# Altium schematic sheet Sheet3.SchDoc
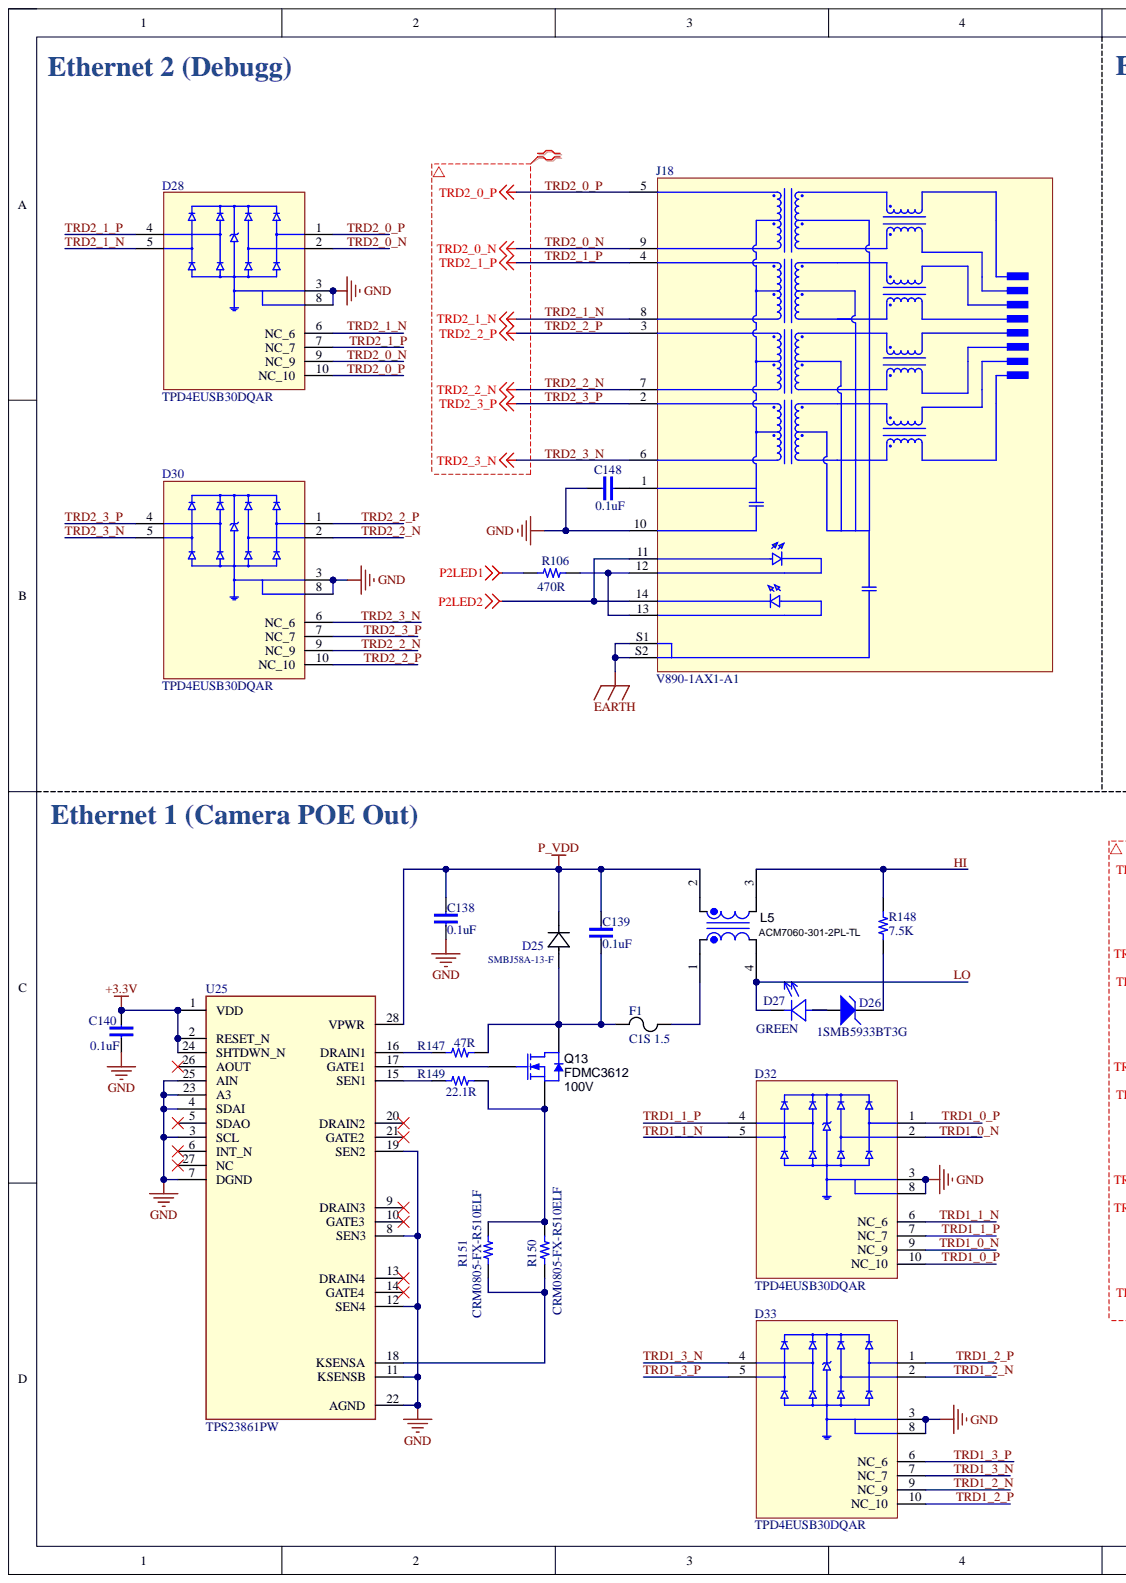
[diagram p0: Sheet3.SchDoc, left]
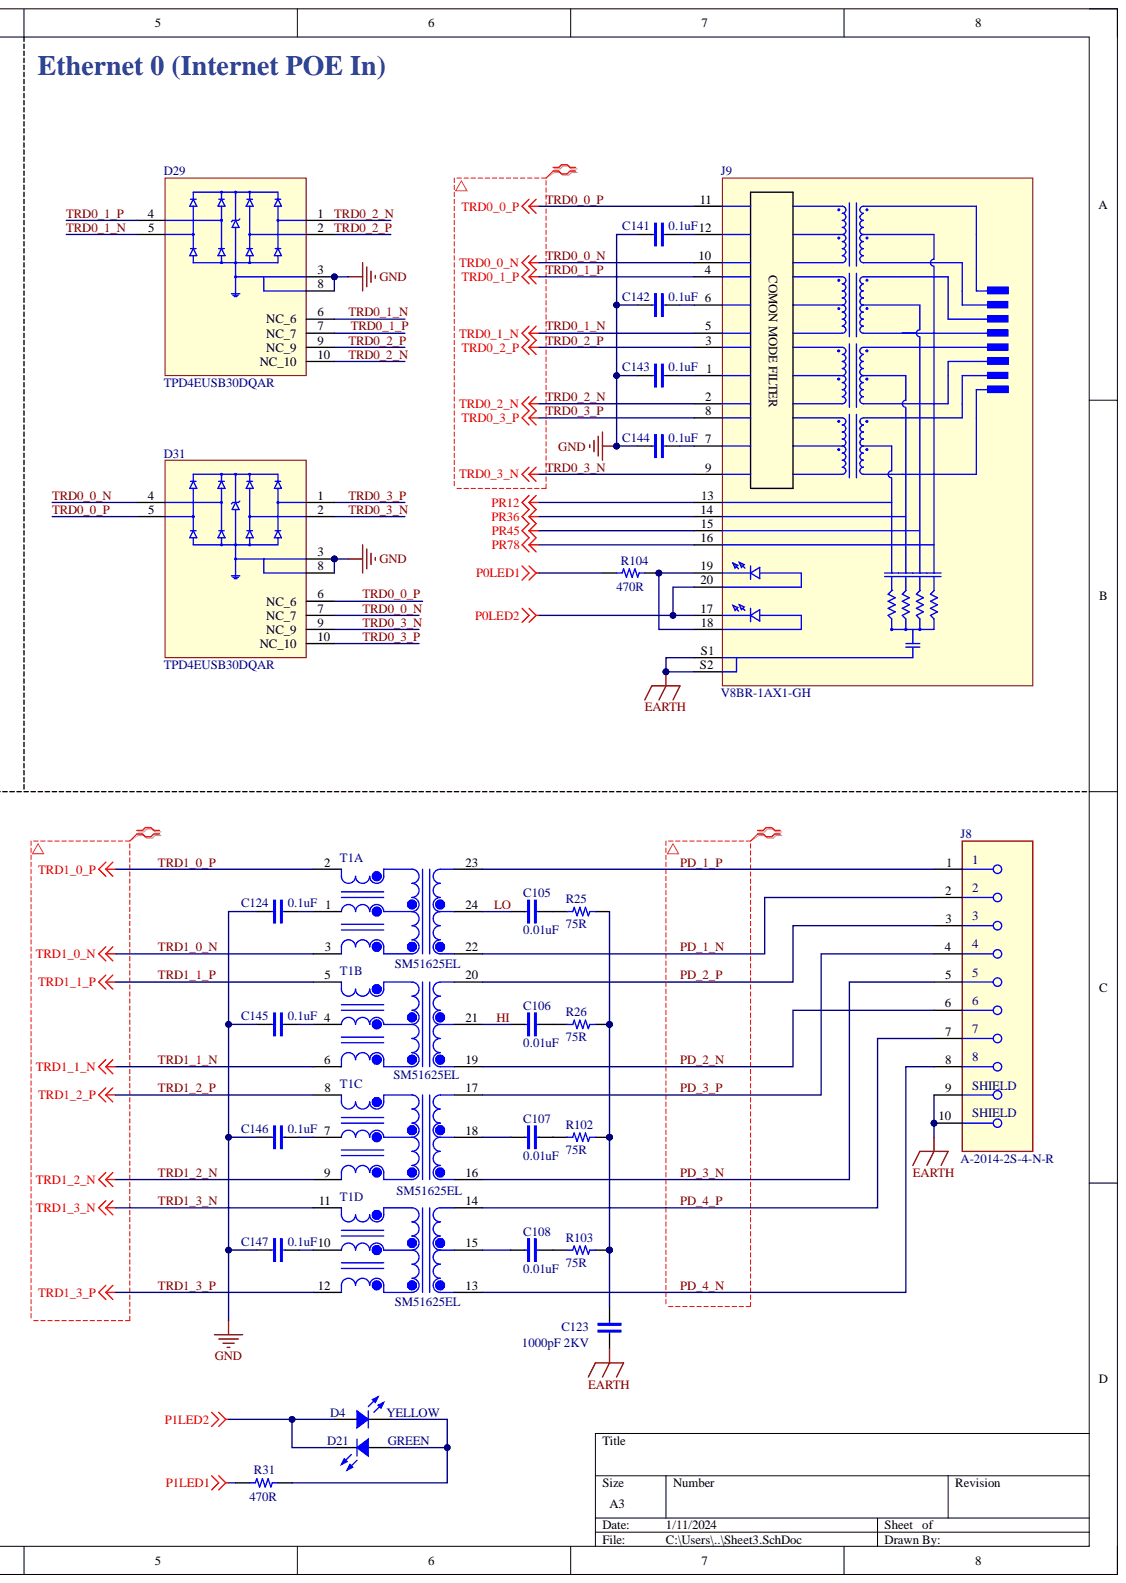
[diagram p1: Sheet3.SchDoc, right]

## Parts
C105  (no value)  [C-0402/1005]
C106  (no value)  [C-0402/1005]
C107  (no value)  [C-0402/1005]
C108  (no value)  [C-0402/1005]
C123  (no value)  [C-0402/1005]
C124  (no value)  [C-0402/1005]
C138  (no value)  [C-0402/1005]
C139  (no value)  [C-0402/1005]
C140  (no value)  [C-0402/1005]
C141  (no value)  [C-0402/1005]
C142  (no value)  [C-0402/1005]
C143  (no value)  [C-0402/1005]
C144  (no value)  [C-0402/1005]
C145  (no value)  [C-0402/1005]
C146  (no value)  [C-0402/1005]
C147  (no value)  [C-0402/1005]
C148  (no value)  [C-0402/1005]
D4  (no value)  [LED-0603-RED]
D21  (no value)  [LED-0603-RED]
D25  Manufacturer_Part_Number: SMBJ58A-13-F  [CR_SM12CA-13-F_DIO]
D26  PartNumber: 1SMB5933BT3G  [ONSC-SMB-2-403A-03_H_V]
D27  (no value)  [LED-0603-Green]
D28  MP: TPD4EUSB30DQAR  [IC_TPD4EUSB30DQAR]
D29  MP: TPD4EUSB30DQAR  [IC_TPD4EUSB30DQAR]
D30  MP: TPD4EUSB30DQAR  [IC_TPD4EUSB30DQAR]
D31  MP: TPD4EUSB30DQAR  [IC_TPD4EUSB30DQAR]
D32  MP: TPD4EUSB30DQAR  [IC_TPD4EUSB30DQAR]
D33  MP: TPD4EUSB30DQAR  [IC_TPD4EUSB30DQAR]
F1  Manufacturer_Part_Number: C1S 1.5  [C1_BEL]
J8  (no value)  [ASSMANN_A-2014-2S-4-N-R]
J9  Manufacturer_Part_Number: V8BR-1AX1-GH  [V8BR1AX1GH]
J18  MP: V890-1AX1-A1  [BEL_V890-1AX1-A1]
L5  ComponentName: ACM7060-301-2PL-TL  [IND_ACM7060_TDK]
Q13  value 100V  PartNumber: FDMC3612  [MLP8_3P3X3P3_ONS]
R25  (no value)  [R-0402/1005]
R26  (no value)  [R-0402/1005]
R31  (no value)  [R-0402/1005]
R102  (no value)  [R-0402/1005]
R103  (no value)  [R-0402/1005]
R104  (no value)  [R-0402/1005]
R106  (no value)  [R-0402/1005]
R147  (no value)  [R-0402/1005]
R148  (no value)  [R-0805/2012]
R149  (no value)  [R-0402/1005]
R150  (no value)  [R-0805/2012]
R151  (no value)  [R-0805/2012]
T1  (no value)  [XFMR_SM51625EL]  (parts A,B,C,D)
U25  Manufacturer_Part_Number: TPS23861PW  [PW0028A_N]

## Nets
+3.3V: C140.1 U25.1(VDD) U25.2(RESET_N) U25.24(SHTDWN_N)
EARTH: C123.2 J8.9 J8.10 J9.S1 J9.S2 J18.S1 J18.S2
GND: C124.1 C138.2 C140.2 C141.1 C142.1 C143.1 C144.1 C145.1 C146.1 C147.1 C148.1 D28.3 D28.8 D29.3 D29.8 D30.3 D30.8 D31.3 D31.8 D32.3 D32.8 D33.3 D33.8 J18.10 U25.3(SCL) U25.4(SDAI) U25.7(DGND) U25.8(SEN3) U25.11(KSENSB) U25.12(SEN4) U25.19(SEN2) U25.22(AGND) U25.23(A3) U25.25(AIN)
P0LED1: R104.1
P0LED2: J9.17 J9.20
P1LED1: R31.1
P1LED2: D4.1 D21.2
P2LED1: R106.1
P2LED2: J18.11 J18.14
P_VDD: C138.1 C139.1 D25.1 L5.2 U25.28(VPWR)
PR12: J9.13
PR36: J9.14
PR45: J9.15
PR78: J9.16
HI: C106.1 L5.3 R148.2 T1.21
LO: C105.1 D27.2 L5.4 T1.24
PD_1_N: J8.2 T1.22
PD_1_P: J8.1 T1.23
PD_2_N: J8.6 T1.19
PD_2_P: J8.3 T1.20
PD_3_N: J8.5 T1.16
PD_3_P: J8.4 T1.17
PD_4_N: J8.8 T1.13
PD_4_P: J8.7 T1.14
TRD0_0_N: D31.4 D31.7(NC_7) J9.10
TRD0_0_P: D31.5 D31.6(NC_6) J9.11
TRD0_1_N: D29.5 D29.6(NC_6) J9.5
TRD0_1_P: D29.4 D29.7(NC_7) J9.4
TRD0_2_N: D29.1 D29.10(NC_10) J9.2
TRD0_2_P: D29.2 D29.9(NC_9) J9.3
TRD0_3_N: D31.2 D31.9(NC_9) J9.9
TRD0_3_P: D31.1 D31.10(NC_10) J9.8
TRD1_0_N: D32.2 D32.9(NC_9) T1.3
TRD1_0_P: D32.1 D32.10(NC_10) T1.2
TRD1_1_N: D32.5 D32.6(NC_6) T1.6
TRD1_1_P: D32.4 D32.7(NC_7) T1.5
TRD1_2_N: D33.2 D33.9(NC_9) T1.9
TRD1_2_P: D33.1 D33.10(NC_10) T1.8
TRD1_3_N: D33.4 D33.7(NC_7) T1.11
TRD1_3_P: D33.5 D33.6(NC_6) T1.12
TRD2_0_N: D28.2 D28.9(NC_9) J18.9
TRD2_0_P: D28.1 D28.10(NC_10) J18.5
TRD2_1_N: D28.5 D28.6(NC_6) J18.8
TRD2_1_P: D28.4 D28.7(NC_7) J18.4
TRD2_2_N: D30.2 D30.9(NC_9) J18.7
TRD2_2_P: D30.1 D30.10(NC_10) J18.3
TRD2_3_N: D30.5 D30.6(NC_6) J18.6
TRD2_3_P: D30.4 D30.7(NC_7) J18.2
NetC105_2: C105.2 R25.1
NetC106_2: C106.2 R26.1
NetC107_1: C107.1 T1.18
NetC107_2: C107.2 R102.1
NetC108_1: C108.1 T1.15
NetC108_2: C108.2 R103.1
NetC123_1: C123.1 R25.2 R26.2 R102.2 R103.2
NetC124_2: C124.2 T1.1
NetC139_2: C139.2 D25.2 F1.1 Q13.5 R147.2
NetC141_2: C141.2 J9.12
NetC142_2: C142.2 J9.6
NetC143_2: C143.2 J9.1
NetC144_2: C144.2 J9.7
NetC145_2: C145.2 T1.4
NetC146_2: C146.2 T1.7
NetC147_2: C147.2 T1.10
NetC148_2: C148.2 J18.1
NetD4_2: D4.2 D21.1 R31.2
NetD26_1: D26.1 R148.1
NetD26_2: D26.2 D27.1
NetF1_2: F1.2 L5.1
NetJ9_18: J9.18 J9.19 R104.2
NetJ18_12: J18.12 J18.13 R106.2
NetQ13_1: Q13.1 R149.2 R150.2 R151.2
NetQ13_4: Q13.4 U25.17(GATE1)
NetR147_1: R147.1 U25.16(DRAIN1)
NetR149_1: R149.1 U25.15(SEN1)
NetR150_1: R150.1 R151.1 U25.18(KSENSA)

Not connected: U25 5(SDAO) 6(INT_N) 9(DRAIN3) 10(GATE3) 13(DRAIN4) 14(GATE4) 20(DRAIN2) 21(GATE2) 26(AOUT) 27(NC)

## Library data of the parts
C105-C108 C123 C124 C138-C148 = (no symbol name): CAP CER 0.1UF 50V X7R 0402; Mfr. Part No.: UMK105B7104KV-FR; Unit Price (USD): 0.15; Value: 100nF; Supplier: Digikey Electronics; Supplier Part No.: 587-3498-1-ND
D4 D21 = (no symbol name): LED RED CLEAR CHIP SMD R/A; Mfr. Part No.: LTST-S270KRKT; Unit Price (USD): 0.24; Supplier: Digikey Electronics; Supplier Part No.: 160-1479-1-ND
D25 = (no symbol name): No Description Available; Mfr_Name: Diodes Inc; Type: DEV; RefDes: RefDes; Copyright: Copyright (C) 2023 Ultra Librarian. All rights reserved.
D26 = (no symbol name): 3 W Zener Voltage Regulator, 2-Pin SMB, Pb-Free, Tape and Reel; Manufacturer: On Semiconductor; VZ Typ (V): 22; ComponentLink1Description: Manufacturer URL; ComponentLink1URL: http://www.onsemi.com/; ComponentLink2Description: Datasheet; ComponentLink2URL: http://www.onsemi.com/pub_link/Collateral/1SMB5913BT3-D.PDF; ComponentLink3Description: Package Specification; ComponentLink3URL: http://www.onsemi.com/pub_link/Collateral/1SMB5913BT3-D.PDF; DatasheetVersion: Rev. 8; Mounting Technology: Surface Mount; PackageDescription: 2-Pin Small Molded Package, J-Bend Lead, Body 4.33 x 3.625 mm; PackageReference: SMB-2-403A-03_H; PackageVersion: Rev. H, 05/2011; Packing: Tape and Reel; RoHS: Pb-Free
D28-D33 = (no symbol name): 4-channel ESD solution for SuperSpeed (6 Gbps) USB 3.0 interface 10-USON -40 to 85; Availability: Unavailable; Package: None; Price: None
F1 = (no symbol name): No Description Available; Mfr_Name: Belfuse; Type: DEV; RefDes: RefDes; Copyright: Copyright (C) 2023 Ultra Librarian. All rights reserved.
J8 = (no symbol name): Jack Modular Connector 8p8c (RJ45, Ethernet) Vertical Unshielded; MF: ASSMANN WSW Components; MP: A-2014-2-4-N-T-R; Digi-Key_Part_Number: AE10396-ND; Package: None; Purchase-URL: https://pricing.snapeda.com/search/part/A-2014-2-4-N-T-R/?ref=eda; SnapEDA_Link: https://www.snapeda.com/parts/A-2014-2-4-N-T-R/ASSMANN%20WSW%20Components/view-part/899781/?ref=snap
J9 = (no symbol name): Conn RJ-45 F 8 POS 1.02mm Solder ST Thru-Hole 20 Terminal 1 Port Tray; Manufacturer_Name: Bel Magnetic Solutions; Geometry.Height: 17.02mm; Datasheet Link: https://belfuse.com/resources/drawings/magneticsolutions/dr-MAG-V8BR-1AX1-GH.pdf; Mouser Part Number: 530-V8BR-1AX1-GH; Mouser Price/Stock: https://www.mouser.co.uk/ProductDetail/Bel-Magnetic-Solutions/V8BR-1AX1-GH?qs=DPoM0jnrROWshWkc%2FQR6HQ%3D%3D
J18 = (no symbol name): MagJack 1000BaseT | 1X1 | G/Y | Vertical | RoHS; MF: Bel Magnetic Solutions; Digi-Key_Part_Number: V890-1AX1-A1A-ND; Mouser-Purchase-URL: https://snapeda.com/shop?store=Mouser&id=423023; Package: None; SnapEDA_Link: https://www.snapeda.com/parts/V890-1AX1-A1/Bel%20Magnetic%20Solutions/view-part/423023/?ref=snap
L5 = (no symbol name): Coupled inductor, 5A, 0.01 ohm, SMD; Manufacturer: TDK; Config: Common Chokes; DCR_Ohm: 0.01; Isat: 5; SymbolCreator: a0799628; U/M: EA; Value: uH; ComponentLink1Description: Datasheet link; ComponentLink1URL: http://www.tdk.co.jp/tefe02/e9713_acm.pdf; CompType: Coupled Inductor; LatestRevisionDate: 2013-10-29; MountType: SMT; PackageReference: 6x3.5x7 mm; PartNumber: ACM7060-301-2PL-TL; Published: 2008-05-16; RoHS: Y; Supplier 1: Digi-Key; Supplier Part Number 1: 445-2214-1-ND; SymbolVersion: 2013-03-27; Publisher: Texas Instruments
Q13 = (no symbol name): MOSFET, N-CH, 100V, 3.3A, 3.3x1x3.3mm; Alternate Manufacturer: None; Manufacturer: Fairchild Semiconductor; ChType: N; IdsMax_A: 3.3; QgTyp_nC: 21; Rdson45_Ohm: 0.11; U/M: EA; Value1: 0.11 ohm; Value2: 21 nC; VdsMax_V: 100; Vgs_V: 4; ComponentLink1Description: Datasheet link; ComponentLink1URL: http://www.fairchildsemi.com/ds/FD/FDMC3612.pdf; CompType: MOSFET; LatestRevisionDate: 2013-08-15; LatestRevisionNote: Added parts.; MountType: SMT; PackageReference: 3.3x1x3.3mm; Published: 2008-06-19; RoHS: Y; Supplier 1: Digi-Key; Supplier 2: Mouser; Supplier 3: Farnell; Supplier Part Number 1: FDMC3612CT-ND; Supplier Part Number 2: 512-FDMC3612; Supplier Part Number 3: 2083247; SymbolVersion: 2008-07-09; Publisher: Texas Instruments
R25 R26 R31 R102-R104 R106 R147-R151 = (no symbol name): RES SMD 51 OHM 0.5% 1/16W 0402; Mfr. Part No.: ERA-2AED510X; Unit Price (USD): 0.21; Value: 51R; Supplier: Digikey Electronics; Supplier Part No.: P51DECT-ND
T1 = (no symbol name): 350ÂµH LAN 10/100/1000 Base-T Pulse Transformer 1CT:1CT Transmitter, 1CT:1CT Receiver Surface Mount; MF: Wurth Electronics; MP: 7490220122; Availability: Unavailable; Package: SMD-2 Wurth Electronics; Price: None; SnapEDA_Link: https://www.snapeda.com/parts/7490220122/Wurth%20Electronics%20Inc./view-part/1426413/?ref=snap
U25 = (no symbol name): No Description Available; Mfr_Name: Texas Instruments; RefDes: RefDes; Type: DEV; Copyright: Copyright (C) 2023 Ultra Librarian. All rights reserved.
D27 = (no symbol name): Supplier: Digikey Electronics
